# Altium schematic sheet ZED-F9T.SchDoc(ZED-F9T)
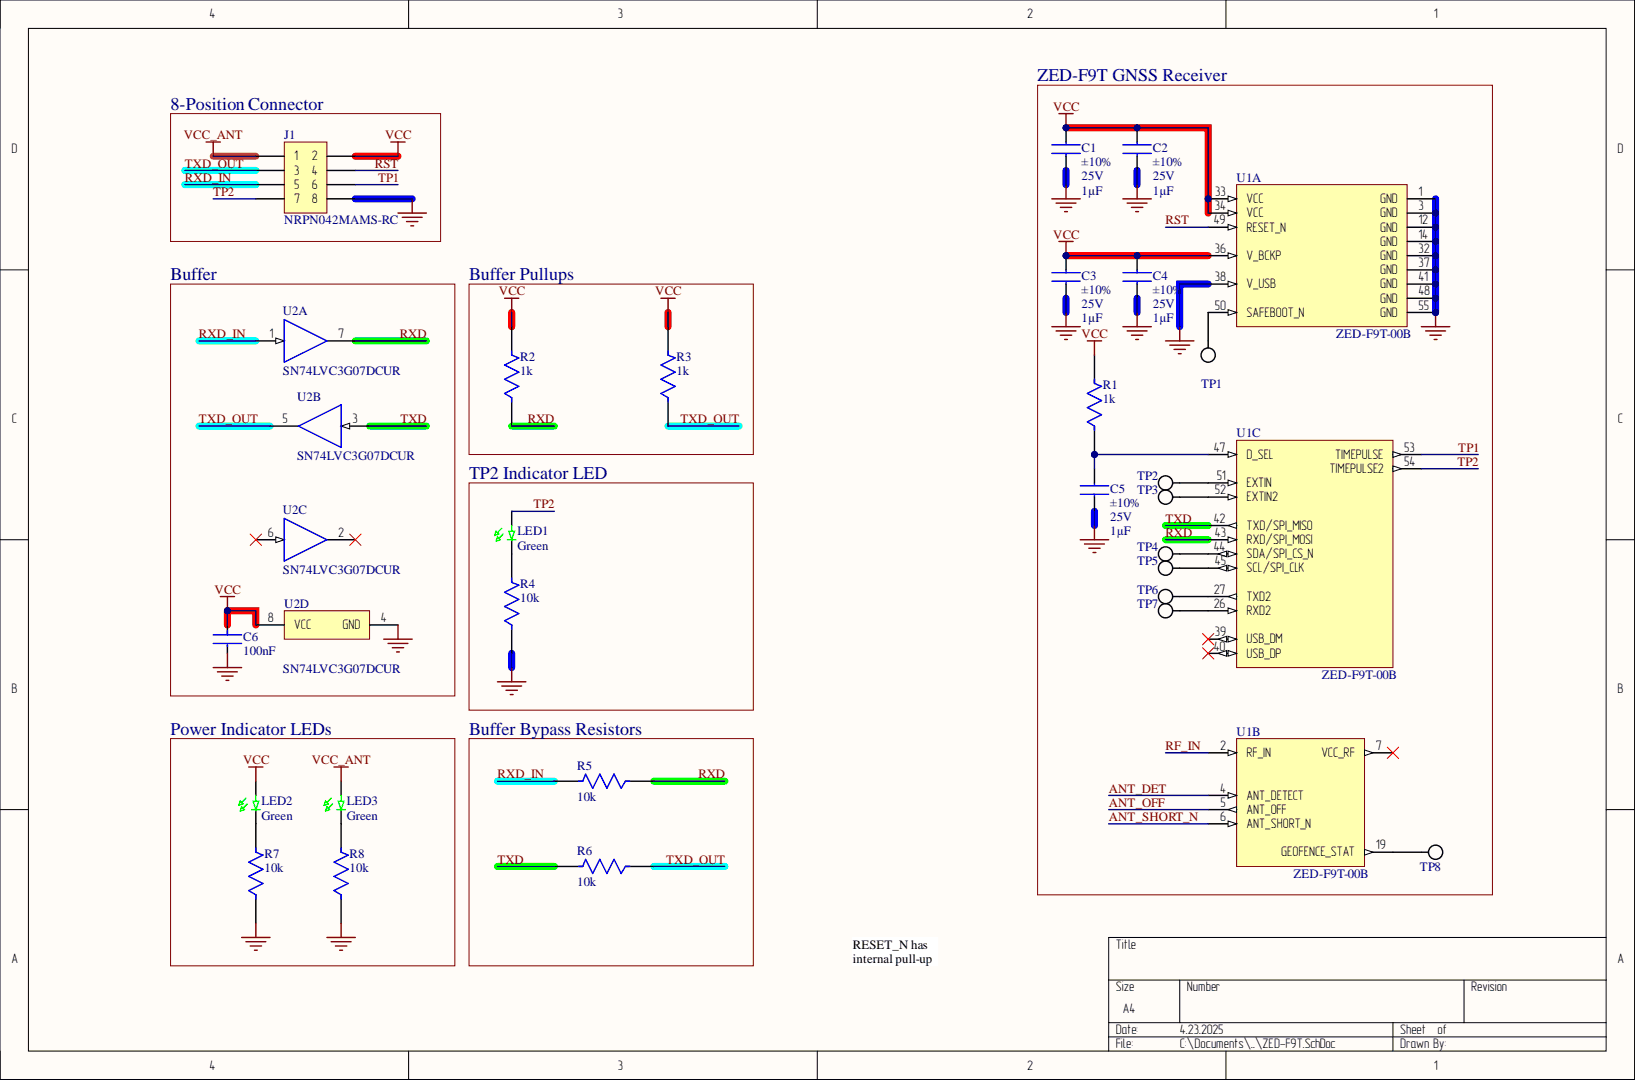
[diagram p0: ZED-F9T.SchDoc]

## Parts
C1  Capacitance: 1µF  Tolerance: ±10%  Voltage Rating: 25V  [CAPC1005X055N]  (hidden comment: Murata_GRM155R61E105KA12D)
C2  Capacitance: 1µF  Tolerance: ±10%  Voltage Rating: 25V  [CAPC1005X055N]  (hidden comment: Murata_GRM155R61E105KA12D)
C3  Capacitance: 1µF  Tolerance: ±10%  Voltage Rating: 25V  [CAPC1005X055N]  (hidden comment: Murata_GRM155R61E105KA12D)
C4  Capacitance: 1µF  Tolerance: ±10%  Voltage Rating: 25V  [CAPC1005X055N]  (hidden comment: Murata_GRM155R61E105KA12D)
C5  Tolerance: ±10%  Voltage Rating: 25V  [CAPC1005X055N]  (hidden comment: Murata_GRM155R61E105KA12D)
C6  100nF  [FP-0402-L_1_0_0_05-W_0_5-IPC_B]
J1  NRPN042MAMS-RC  [NRPN042MAMS-RC]
LED1  Color: Green  [LEDS160X80X110-2N_LXFM0603-GR]  (hidden comment: Lumex_SML-LXFM0603SUGCTR)
LED2  Color: Green  [LEDS160X80X110-2N_LXFM0603-GR]  (hidden comment: Lumex_SML-LXFM0603SUGCTR)
LED3  Color: Green  [LEDS160X80X110-2N_LXFM0603-GR]  (hidden comment: Lumex_SML-LXFM0603SUGCTR)
R1  1k  [FP-RMCF0402-MFG]
R2  1k  [FP-RMCF0402-MFG]
R3  1k  [FP-RMCF0402-MFG]
R4  10k  [FP-RMCF0402-IPC_C]
R5  10k  [FP-RMCF0402-IPC_C]
R6  10k  [FP-RMCF0402-IPC_C]
R7  10k  [FP-RMCF0402-IPC_C]
R8  10k  [FP-RMCF0402-IPC_C]
TP1  SMT_100DIA  [TP001V_SMT_100DIA]  (value not drawn)  (no BOM)
TP2  SMT_100DIA  [TP001V_SMT_100DIA]  (value not drawn)  (no BOM)
TP3  SMT_100DIA  [TP001V_SMT_100DIA]  (value not drawn)  (no BOM)
TP4  SMT_100DIA  [TP001V_SMT_100DIA]  (value not drawn)  (no BOM)
TP5  SMT_100DIA  [TP001V_SMT_100DIA]  (value not drawn)  (no BOM)
TP6  SMT_100DIA  [TP001V_SMT_100DIA]  (value not drawn)  (no BOM)
TP7  SMT_100DIA  [TP001V_SMT_100DIA]  (value not drawn)  (no BOM)
TP8  SMT_100DIA  [TP001V_SMT_100DIA]  (value not drawn)  (no BOM)
U1  ZED-F9T-00B  [GPS_ZED-F9T]  (parts A,B,C)
U2  SN74LVC3G07DCUR  [DCU0008A_N]  (parts A,B,C,D)

## Nets
GND: C1.2 C2.2 C3.2 C4.2 C5.2 C6.2 J1.8 R4.1 R7.1 R8.1 U1.1(GND) U1.3(GND) U1.12(GND) U1.14(GND) U1.32(GND) U1.37(GND) U1.38(V_USB) U1.41(GND) U1.48(GND) U1.55(GND) U2.4(GND)
VCC: C1.1 C2.1 C3.1 C4.1 C6.1 J1.2 LED2.A R1.2 R2.2 R3.2 U1.33(VCC) U1.34(VCC) U1.36(V_BCKP) U2.8(VCC)
VCC_ANT: J1.1 LED3.A
ANT_DET: U1.4(ANT_DETECT)
ANT_OFF: U1.5(ANT_OFF)
ANT_SHORT_N: U1.6(ANT_SHORT_N)
RF_IN: U1.2(RF_IN)
RST: J1.4 U1.49(RESET_N)
RXD: R2.1 R5.1 U1.43(RXD/SPI_MOSI) U2.7
RXD_IN: J1.5 R5.2 U2.1
TP1: J1.6 U1.53(TIMEPULSE)
TP2: J1.7 LED1.A U1.54(TIMEPULSE2)
TXD: R6.2 U1.42(TXD/SPI_MISO) U2.3
TXD_OUT: J1.3 R3.1 R6.1 U2.5
NetC5_1: C5.1 R1.1 U1.47(D_SEL)
NetLED1_K: LED1.K R4.2
NetLED2_K: LED2.K R7.2
NetLED3_K: LED3.K R8.2
NetTP1_1: TP1.1 U1.50(SAFEBOOT_N)
NetTP2_1: TP2.1 U1.51(EXTIN)
NetTP3_1: TP3.1 U1.52(EXTIN2)
NetTP4_1: TP4.1 U1.44(SDA/SPI_CS_N)
NetTP5_1: TP5.1 U1.45(SCL/SPI_CLK)
NetTP6_1: TP6.1 U1.27(TXD2)
NetTP7_1: TP7.1 U1.26(RXD2)
NetTP8_1: TP8.1 U1.19(GEOFENCE_STAT)

Not connected: U1 7(VCC_RF) 39(USB_DM) 40(USB_DP); U2 2 6

## Library data of the parts
C1-C4 = CAP-CMP-00365-3: CAP, CER, X5R, 1UF, 10%, 25V, 0402, 0.55MM T; Internal Part Number: 825-00099-00; Manufacturer: Murata; Polarization: Non-polar; Applications: General Purpose; Case/Package: 0402 (1005 Metric); Category: Ceramic Capacitors; Component Kind: Standard; Composition: Ceramic; Datasheet URL: https://www.digikey.com/en/products/detail/murata-electronics/GRM155R61E105KA12D/4905139?s=N4IgTCBcDaIOICUCyBGArGhA2FBRFADGgNICCKYAIiALoC%2BQA; Height: 0.022" (0.55mm); Manufacturer Part Number: GRM155R61E105KA12D; Max Operating Temperature: 85°C; Min Operating Temperature: -55°C; Mounting Type: Surface Mount, MLCC; RoHS: Yes; Series: GRM155R61E; Size / Dimension: 0.039" L x 0.020" W (1.00mm x 0.50mm); Supplier 1: Digikey; Supplier Part Number 1: 490-10017-1-ND; Temperature Coefficient: X5R; Library Name: RL Research Altium Server
C5 = CAP-CMP-00365-3: CAP, CER, X5R, 1UF, 10%, 25V, 0402, 0.55MM T; Internal Part Number: 825-00099-00; Manufacturer: Murata; Capacitance: 1µF; Polarization: Non-polar; Applications: General Purpose; Case/Package: 0402 (1005 Metric); Category: Ceramic Capacitors; Component Kind: Standard; Composition: Ceramic; Datasheet URL: https://www.digikey.com/en/products/detail/murata-electronics/GRM155R61E105KA12D/4905139?s=N4IgTCBcDaIOICUCyBGArGhA2FBRFADGgNICCKYAIiALoC%2BQA; Height: 0.022" (0.55mm); Manufacturer Part Number: GRM155R61E105KA12D; Max Operating Temperature: 85°C; Min Operating Temperature: -55°C; Mounting Type: Surface Mount, MLCC; RoHS: Yes; Series: GRM155R61E; Size / Dimension: 0.039" L x 0.020" W (1.00mm x 0.50mm); Supplier 1: Digikey; Supplier Part Number 1: 490-10017-1-ND; Temperature Coefficient: X5R; Library Name: RL Research Altium Server
C6 = CMP-14477-000330-2: None; Dielectric: X5R; Tolerance: 10%; Voltage Rating: 50V; Capacitance: 100nF; Case Code (Imperial): 0402; Case Code (Metric): 1005; Case/Package: 0402; Component Kind: Standard; Halogen Free: Halogen Free; Height: 0.5mm; Length: 0.039inch; Library Name: Altium Content Vault; Max Operating Temperature: 85°C; Min Operating Temperature: -55°C; Mount: Surface Mount; Package Quantity: 10000; Packaging: Tape and Reel; RoHS Compliant: Yes; Simulation: UMK105BJ104_V-F; Termination: SMD/SMT; Thickness: 0.022inch; Voltage Rating (DC): 50V; Width: 0.02inch
J1 = NRPN042MAMS-RC: Dual Row 8 Position 2 mm Pitch Surface Mount Header; Manufacturer: Sullins; Connector Type: Male Pin; Number of Positions: 8; Pitch: 0.079inch; Category: Connectors; Color: Black; Component Kind: Standard; ComponentLink1Description: https://datasheet.ciiva.com/711/nrpnxx2mamx-rc-10495-d-711179.pdf?src-supplier=Digi-Key; ComponentLink1URL: https://datasheet.ciiva.com/711/nrpnxx2mamx-rc-10495-d-711179.pdf?src-supplier=Digi-Key; Contact Material: Brass; Contact Mating Length: 0.141inch; Contact Plating: Gold; Contact Type: Male Pin; Height: 1.5mm; Housing Material: Nylon; Lead Free: Lead Free; Length: 8mm; Library Name: GNSS_Receiver_Library.IntLib; Manufacturer Part Number: NRPN042MAMS-RC; Mount: Surface Mount; Number of Rows: 2; Package Quantity: 1000; Packaging: Bulk; Pricing 1: 1=0.76000, 76=0.60237, 152=0.57618, 456=0.47143, 988=0.41904, 4940=0.37830 (USD); RoHS: Yes; RoHS Compliant: Yes; Row Spacing: 0.079inch; Stock 1: 10801; Supplier 1: Digi-Key; Supplier Part Number 1: S6009-04-ND; Termination: Solder; Width: 4mm
LED1-LED3 = LED-CMP-00021-2: LED, GREEN, 574NM, 20MA, 20MCD MIN, 2.5VF MAX, 0603, 1.10MM T; Internal Part Number: 838-00422-00; Manufacturer: Lumex Opto/Components Inc.; Configuration: Independent; Lens Size: 1.20mm x 0.80mm; Lens Style: Rectangle with Flat Top; Lens Transparency: Clear; Millicandela Rating: 50mcd; Viewing Angle: 120°; Voltage - Forward (Vf) (Typ): 2.2V; Case/Package: 0603 (1608 Metric); Category: LED Indication - Discrete; Component Kind: Standard; Current - Test: 20mA; Datasheet URL: https://www.digikey.com/en/products/detail/lumex-opto-components-inc/SML-LXFM0603SUGCTR/7364547?s=N4IgTCBcDaIMoFkAyBaJANAYggDANhwGY4BVAcQGEAVAJRAF0BfIA; Height (Max): 1.10mm; Lens Color: Green; Manufacturer Part Number: SML-LXFM0603SUGCTR; Max Operating Temperature: 85°C; Min Operating Temperature: -40°C; Mounting Type: Surface Mount; RoHS: Yes; Size / Dimension: 1.60mm L x 0.80mm W; Supplier 1: Digikey; Supplier Part Number 1: 67-2320-1-ND; Wavelength - Peak: 574nm; Library Name: RL Research Altium Server
R1-R3 = CMP-26527-000026-1: 1kΩ ±1% 0.063W 0402 Thick Film Chip Resistor AEC-Q200 compliant; Max Power Dissipation: 63mW; Resistance: 1kR; Temperature Coefficient: 100ppm/°C; Case Code (Imperial): 0402; Case Code (Metric): 1005; Case/Package: 0402; Component Kind: Standard; Construction: Rectangular; Contact Plating: Tin; Depth: 0.5mm; Features: Automotive AEC-Q200; Height: 0.016inch; Lead Free: Lead Free; Length: 1mm; Library Name: Altium Content Vault; Max Operating Temperature: 155°C; Military Standard: Not; Min Operating Temperature: -55°C; Mount: Surface Mount; Number of Terminations: 2; Packaging: Tape and Reel; Power Rating: 63mW; Radiation Hardening: No; Resistor Type: Thick Film; RoHS Compliant: Yes; Series: RMCF; Size Code: 0402; Tolerance: 1%; Width: 0.02inch
R4-R8 = CMP-26527-000128-1: 10kΩ ±1% 0.063W 0402 Thick Film Chip Resistor AEC-Q200 compliant; Max Power Dissipation: 63mW; Power Rating: 62.5mW; Resistance: 10kR; Temperature Coefficient: 100ppm/°C; Case Code (Imperial): 0402; Case Code (Metric): 1005; Case/Package: 0402; Component Kind: Standard; Construction: Rectangular; Contact Plating: Tin; Depth: 0.5mm; Features: Automotive AEC-Q200; Height: 0.016inch; Lead Free: Lead Free; Length: 1mm; Library Name: Altium Content Vault; Max Operating Temperature: 155°C; Military Standard: Not; Min Operating Temperature: -55°C; Mount: Surface Mount; Number of Terminations: 2; Packaging: Tape and Reel; Radiation Hardening: No; Resistor Type: Thick Film; RoHS Compliant: Yes; Series: RMCF; Size Code: 0402; Tolerance: 1%; Width: 0.02inch
TP1-TP8 = TPS-CMP-00004-3: TEST POINT, PC, SMT, 1.00MM DIA; Category: Test Points; Mounting Type: 1.00mm Dia Pad; Size / Dimension: 1.00mm Dia Pad; Type: PC Test Point, Surface Mount; Library Name: RL Research Altium Server
U1 = GPS_ZED-F9T: Multi-band GNSS Receiver with Nanosecond-level Timing Accuracy; Manufacturer: u-blox; Interface: I2C,SPI,USB,UART; Sensitivity: -166dBm; Case/Package: SMD/SMT; Category: RF Receivers, Transceivers; Component Kind: Standard; ComponentLink1Description: https://www.u-blox.com/sites/default/files/ZED-F9T_ProductSummary_%28UBX-18011626%29.pdf?src-supplier=Digi-Key; ComponentLink1URL: https://www.u-blox.com/sites/default/files/ZED-F9T_ProductSummary_%28UBX-18011626%29.pdf?src-supplier=Digi-Key; Library Name: GNSS_Receiver_Library.IntLib; Manufacturer Part Number: ZED-F9T-00B; Max Operating Temperature: 85°C; Min Operating Temperature: -40°C; Packaging: Tape and Reel; Pricing 1: 1=199.00000, 10=198.80000, 50=180.89020 (USD); RoHS: Yes; Stock 1: 826; Supplier 1: Digi-Key; Supplier Part Number 1: 672-1197-1-ND
U2 = CMP-0859-00615-3: Triple Buffer/Driver With Open-Drain Output, DCU0008A, LARGE T&R; Manufacturer: Texas Instruments; 3-State Output: No; Bits(#): 3; F @ Nom Voltage(Max)(Mhz): 150; ICC @ Nom Voltage(Max)(mA): 0.01; Input Type: CMOS/TTL; Logic: True; Output Drive (IOL/IOH)(Max)(mA): 32/-32; Output Type: CMOS; Rating: Catalog; Sub-Family: Non-Inverting Buffer/Driver; Technology Family: LVC; tpd @ Nom Voltage(Max)(ns): 8.3,4.8,4.2,3.4; VCC(Max)(V): 5.5; VCC(Min)(V): 1.65; Voltage(Nom)(V): 1.8,2.5,3.3,5; Component Kind: Standard; DatasheetVersion: SCES365K; Generic Part Number: SN74LVC3G07; Library Name: Altium Content Vault; Operating Temperature Range(C): -40 to 125,-40 to 85; PackageReference: DCU0008A; Schmitt Trigger: No
